# Altium schematic sheet Power.SchDoc
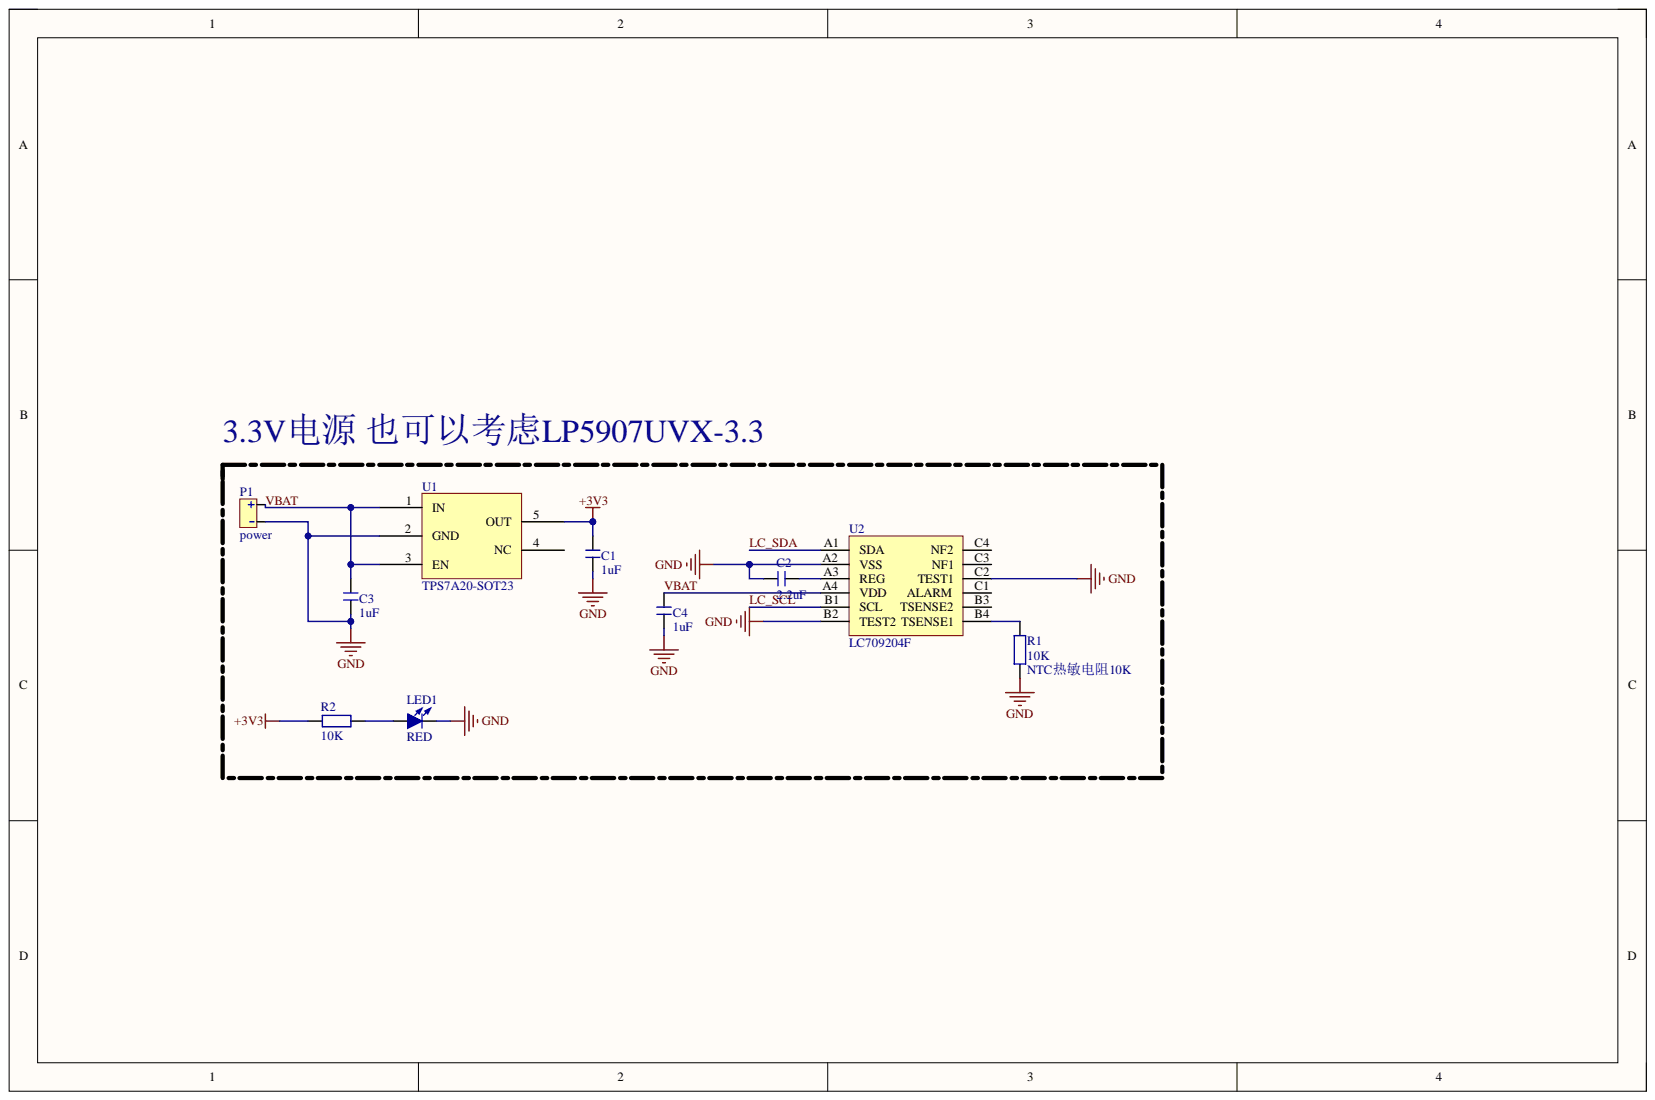
[diagram p0: Power.SchDoc]

## Parts
C1  1uF  [C 0201_L]
C2  2.2uF  [C 0201_L]
C3  1uF  [C 0201_L]
C4  1uF  [C 0201_L]
LED1  RED  [LED-SMD_L0.7-W0.4-RD_BLUE - duplicate]
P1  power  [CONN-SMD_SM02B-SURS-TF-LF-SN]
R1  10K  [R0201]
R2  10K  [R 0201_L]
U1  TPS7A20-SOT23  [SOT-23-5_L2.9-W1.6-P0.95-LS2.8-BR]
U2  LC709204F  [WLCSP-12_L2.0-W1.5-R3-C4-P0.40-TL]

## Nets
+3V3: C1.2 R2.1 U1.5(OUT)
GND: C1.1 C2.2 C3.1 C4.1 LED1.2 P1.2 R1.1 U1.2(GND) U2.A2(VSS) U2.B2(TEST2) U2.C2(TEST1)
LC_SCL: U2.B1(SCL)
LC_SDA: U2.A1(SDA)
VBAT: C3.2 C4.2 P1.1 U1.1(IN) U1.3(EN) U2.A4(VDD)
NetC2_1: C2.1 U2.A3(REG)
NetLED1_1: LED1.1 R2.2
NetR1_2: R1.2 U2.B4(TSENSE1)

Not connected: U1 4(NC); U2 B3(TSENSE2) C1(ALARM) C3(NF1) C4(NF2)

## Library data of the parts
C1-C4 = C: 贴片电容; BOM名称: 无极性贴片电容; Component Kind: Standard; Library Name: 0.1 - 电阻-电容-电感.SchLib
  C1 C3: Value: 1uF
  C2: value: 2.2uF
  C4: value: 1uF
LED1 = LED-SMD: 贴片LED; BOM名称: 贴片LED; Color: RED; Component Kind: Standard; Library Name: 0.3 - LED发光二极管.SchLib
R1 = R: NTC热敏电阻 10K; BOM名称: NTC热敏电阻10K; Component Kind: Standard; Library Name: 0.1 - 电阻-电容-电感.SchLib; Value: 10K
R2 = R: 贴片电阻; BOM名称: 贴片电阻; Component Kind: Standard; Library Name: 0.1 - 电阻-电容-电感.SchLib; Value: 10K
U2 = LC709203F: Component Kind: Standard; Library Name: nrf.SchLib
P1 = power: Component Kind: Standard; Library Name: RNS_System.SchLib
U1 = TPS7A20-SOT23: Component Kind: Standard; Library Name: rhd2216.SchLib
